# Altium schematic sheet 8_HDMI.SchDoc
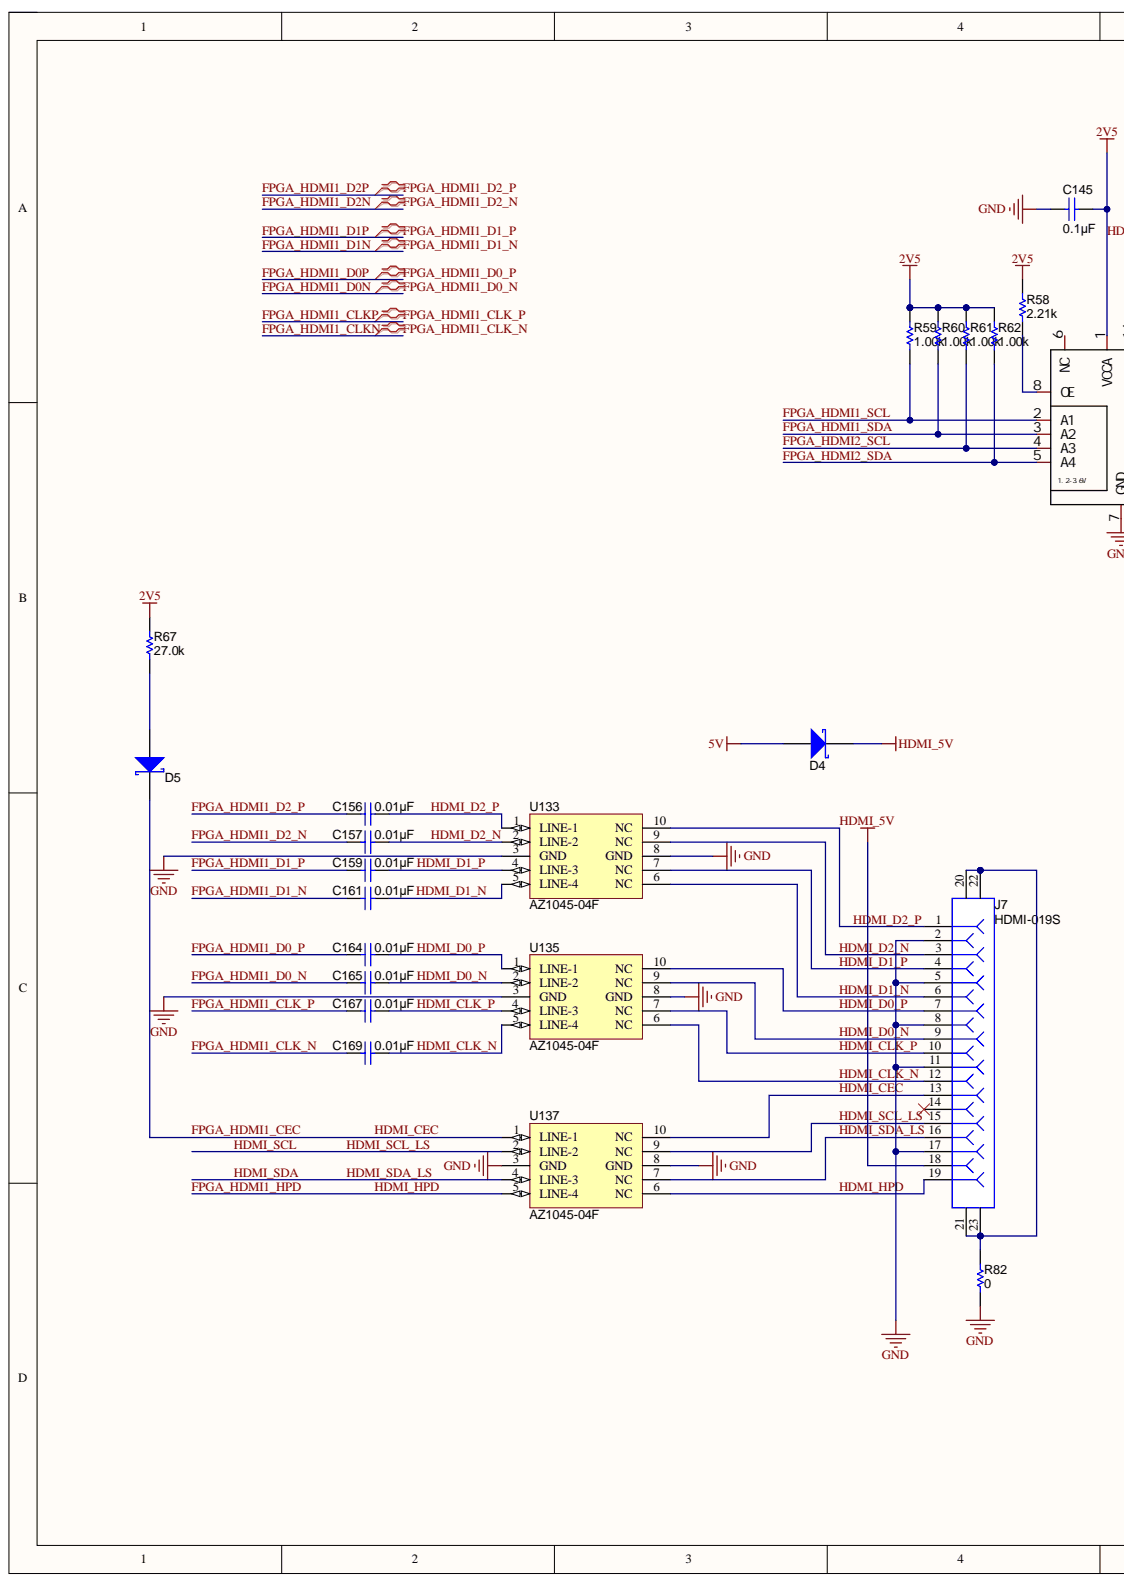
[diagram p0: 8_HDMI.SchDoc, left]
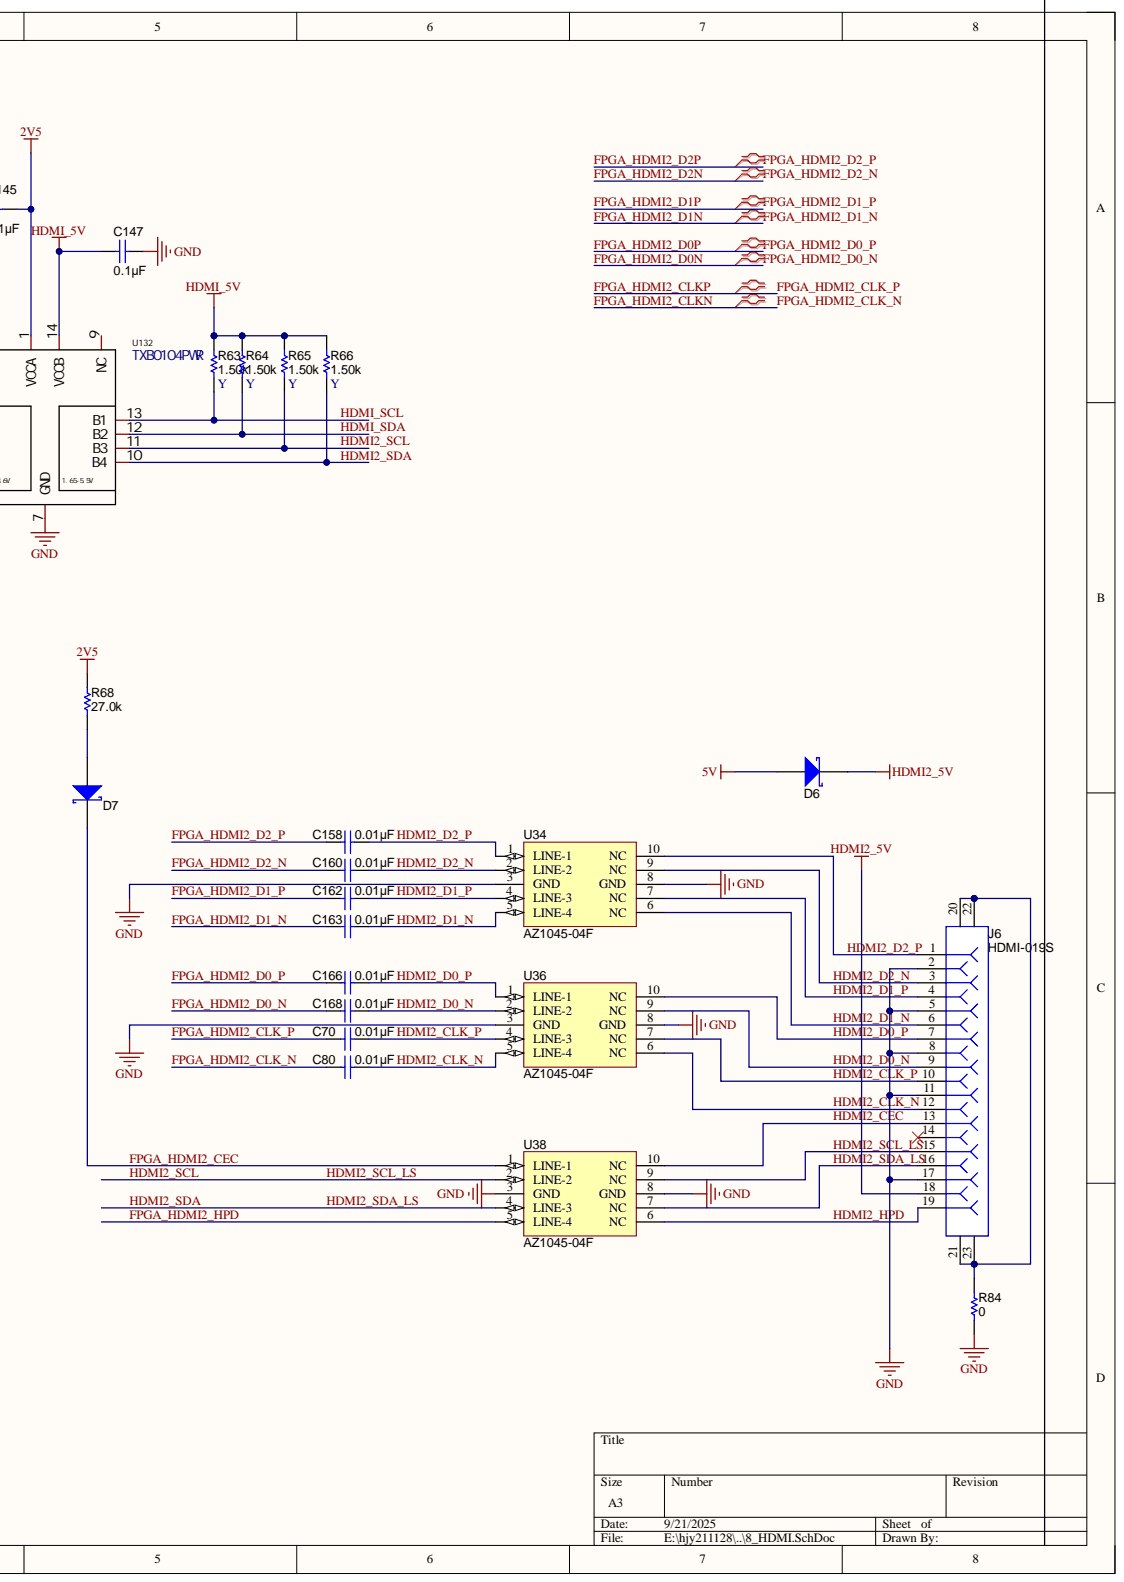
[diagram p1: 8_HDMI.SchDoc, right]

## Parts
C70  ValueDisplayed: 0.01µF  [0402]  (hidden comment: C0402C103J5RACTU)
C80  ValueDisplayed: 0.01µF  [0402]  (hidden comment: C0402C103J5RACTU)
C145  ValueDisplayed: 0.1µF  [0603]  (hidden comment: C0603C104J3RAC)
C147  ValueDisplayed: 0.1µF  [0603]  (hidden comment: C0603C104J3RAC)
C156  ValueDisplayed: 0.01µF  [0402]  (hidden comment: C0402C103J5RACTU)
C157  ValueDisplayed: 0.01µF  [0402]  (hidden comment: C0402C103J5RACTU)
C158  ValueDisplayed: 0.01µF  [0402]  (hidden comment: C0402C103J5RACTU)
C159  ValueDisplayed: 0.01µF  [0402]  (hidden comment: C0402C103J5RACTU)
C160  ValueDisplayed: 0.01µF  [0402]  (hidden comment: C0402C103J5RACTU)
C161  ValueDisplayed: 0.01µF  [0402]  (hidden comment: C0402C103J5RACTU)
C162  ValueDisplayed: 0.01µF  [0402]  (hidden comment: C0402C103J5RACTU)
C163  ValueDisplayed: 0.01µF  [0402]  (hidden comment: C0402C103J5RACTU)
C164  ValueDisplayed: 0.01µF  [0402]  (hidden comment: C0402C103J5RACTU)
C165  ValueDisplayed: 0.01µF  [0402]  (hidden comment: C0402C103J5RACTU)
C166  ValueDisplayed: 0.01µF  [0402]  (hidden comment: C0402C103J5RACTU)
C167  ValueDisplayed: 0.01µF  [0402]  (hidden comment: C0402C103J5RACTU)
C168  ValueDisplayed: 0.01µF  [0402]  (hidden comment: C0402C103J5RACTU)
C169  ValueDisplayed: 0.01µF  [0402]  (hidden comment: C0402C103J5RACTU)
D4  1N5819  [SOD-123F]  (value not drawn)
D5  1N5819  [SOD-123F]  (value not drawn)
D6  1N5819  [SOD-123F]  (value not drawn)
D7  1N5819  [SOD-123F]  (value not drawn)
J6  HDMI-019S  [CONN_TE_1747981-1]
J7  HDMI-019S  [CONN_TE_1747981-1]
R58  value 2.21k  [0402]  (hidden comment: CRCW04022K21FKED)
R59  value 1.00k  [0603]  (hidden comment: CRCW06031K00FKEA)
R60  value 1.00k  [0603]  (hidden comment: CRCW06031K00FKEA)
R61  value 1.00k  [0603]  (hidden comment: CRCW06031K00FKEA)
R62  value 1.00k  [0603]  (hidden comment: CRCW06031K00FKEA)
R63  Y  value 1.50k  [0603]
R64  Y  value 1.50k  [0603]
R65  Y  value 1.50k  [0603]
R66  Y  value 1.50k  [0603]
R67  value 27.0k  [0603]  (hidden comment: RC0603FR-0727KL)
R68  value 27.0k  [0603]  (hidden comment: RC0603FR-0727KL)
R82  value 0  [0603]  (hidden comment: CRCW06030000Z0EA)
R84  value 0  [0603]  (hidden comment: CRCW06030000Z0EA)
U34  AZ1045-04F  [DQA0010A]
U36  AZ1045-04F  [DQA0010A]
U38  AZ1045-04F  [DQA0010A]
U132  TXB0104PWR  [TSSOP-14_L5.0-W4.4-P0.65-LS6.4-BL]
U133  AZ1045-04F  [DQA0010A]
U135  AZ1045-04F  [DQA0010A]
U137  AZ1045-04F  [DQA0010A]

## Nets
2V5: C145.1 R58.2 R59.2 R60.2 R61.2 R62.2 R67.2 R68.2 U132.1(VCCA)
5V: D4.2 D6.2
GND: C145.2 C147.1 J6.2 J6.5 J6.8 J6.11 J6.17 J7.2 J7.5 J7.8 J7.11 J7.17 R82.1 R84.1 U34.3(GND) U34.8(GND) U36.3(GND) U36.8(GND) U38.3(GND) U38.8(GND) U132.7(GND) U133.3(GND) U133.8(GND) U135.3(GND) U135.8(GND) U137.3(GND) U137.8(GND)
HDMI2_5V: D6.1 J6.18
HDMI_5V: C147.2 D4.1 J7.18 R63.2 R64.2 R65.2 R66.2 U132.14(VCCB)
FPGA_HDMI1_CEC: D5.1 J7.13 U137.1(LINE-1) U137.10(NC)
FPGA_HDMI1_CLK_N: C169.2
FPGA_HDMI1_CLK_P: C167.2
FPGA_HDMI1_D0_N: C165.2
FPGA_HDMI1_D0_P: C164.2
FPGA_HDMI1_D1_N: C161.2
FPGA_HDMI1_D1_P: C159.2
FPGA_HDMI1_D2_N: C157.2
FPGA_HDMI1_D2_P: C156.2
FPGA_HDMI1_HPD: J7.19 U137.5(LINE-4) U137.6(NC)
FPGA_HDMI1_SCL: R59.1 U132.2(A1)
FPGA_HDMI1_SDA: R60.1 U132.3(A2)
FPGA_HDMI2_CEC: D7.1 U38.1(LINE-1)
FPGA_HDMI2_CLK_N: C80.2
FPGA_HDMI2_CLK_P: C70.2
FPGA_HDMI2_D0_N: C168.2
FPGA_HDMI2_D0_P: C166.2
FPGA_HDMI2_D1_N: C163.2
FPGA_HDMI2_D1_P: C162.2
FPGA_HDMI2_D2_N: C160.2
FPGA_HDMI2_D2_P: C158.2
FPGA_HDMI2_HPD: U38.5(LINE-4)
FPGA_HDMI2_SCL: R61.1 U132.4(A3)
FPGA_HDMI2_SDA: R62.1 U132.5(A4)
HDMI2_CEC: J6.13 U38.10(NC)
HDMI2_CLK_N: C80.1 J6.12 U36.5(LINE-4) U36.6(NC)
HDMI2_CLK_P: C70.1 J6.10 U36.4(LINE-3) U36.7(NC)
HDMI2_D0_N: C168.1 J6.9 U36.2(LINE-2) U36.9(NC)
HDMI2_D0_P: C166.1 J6.7 U36.1(LINE-1) U36.10(NC)
HDMI2_D1_N: C163.1 J6.6 U34.5(LINE-4) U34.6(NC)
HDMI2_D1_P: C162.1 J6.4 U34.4(LINE-3) U34.7(NC)
HDMI2_D2_N: C160.1 J6.3 U34.2(LINE-2) U34.9(NC)
HDMI2_D2_P: C158.1 J6.1 U34.1(LINE-1) U34.10(NC)
HDMI2_HPD: J6.19 U38.6(NC)
HDMI2_SCL: J6.15 R65.1 U38.2(LINE-2) U38.9(NC) U132.11(B3)
HDMI2_SDA: J6.16 R66.1 U38.4(LINE-3) U38.7(NC) U132.10(B4)
HDMI_CLK_N: C169.1 J7.12 U135.5(LINE-4) U135.6(NC)
HDMI_CLK_P: C167.1 J7.10 U135.4(LINE-3) U135.7(NC)
HDMI_D0_N: C165.1 J7.9 U135.2(LINE-2) U135.9(NC)
HDMI_D0_P: C164.1 J7.7 U135.1(LINE-1) U135.10(NC)
HDMI_D1_N: C161.1 J7.6 U133.5(LINE-4) U133.6(NC)
HDMI_D1_P: C159.1 J7.4 U133.4(LINE-3) U133.7(NC)
HDMI_D2_N: C157.1 J7.3 U133.2(LINE-2) U133.9(NC)
HDMI_D2_P: C156.1 J7.1 U133.1(LINE-1) U133.10(NC)
HDMI_SCL: J7.15 R63.1 U132.13(B1) U137.2(LINE-2) U137.9(NC)
HDMI_SDA: J7.16 R64.1 U132.12(B2) U137.4(LINE-3) U137.7(NC)
NetD5_2: D5.2 R67.1
NetD7_2: D7.2 R68.1
NetJ6_20: J6.20 J6.21 J6.22 J6.23 R84.2
NetJ7_20: J7.20 J7.21 J7.22 J7.23 R82.2
NetR58_1: R58.1 U132.8(OE)

Not connected: J6 14; J7 14; U132 6(NC) 9(NC)

## Library data of the parts
C70 C80 C156-C169 = C0402C103J5RACTU: CAP, CERM, 0.01 µF, 50 V, +/- 5%, X7R, 0402; Manufacturer: Kemet; Cap_F: 0.00000001; CompType: Capacitor; Polarized: N; TaMax_C: 125; TaMin_C: -55; Tolerance_%: 5; U/M: EA; Value: 0.01uF; Value1: Unknown; Value2: 50V; VDC_V: 50; Cap_Case: 0402; Component Kind: Standard; ComponentLink1Description: Datasheet link; ComponentLink1URL: http://www.kemet.com/Lists/ProductCatalog/Attachments/53/KEM_C1002_X7R_SMD.pdf; LatestRevisionDate: 2015-05-02; MountType: SMT; PackageReference: 0402; PartNumber: C0402C103J5RACTU; Published: 2008-06-19; RoHS: Y; Signal Integrity: Cap; Simulation: Cap; Supplier 1: Digi-Key; Supplier 2: Mouser; Supplier 3: Farnell; Supplier Part Number 1: 399-7758-1-ND; Supplier Part Number 2: 80-C0402C103J5R; Supplier Part Number 3: 2413922; SymbolVersion: 2008-07-09; Technology: Ceramic; TempCo: X7R; Library Name: 底板集成库.IntLib; Publisher: Texas Instruments
C145 C147 = C0603C104J3RAC: CAP, CERM, 0.1 µF, 25 V, +/- 5%, X7R, 0603; Manufacturer: Kemet; Cap_F: 0.0000001; CompType: Capacitor; Polarized: N; TaMax_C: 125; TaMin_C: -55; Tolerance_%: 5; U/M: EA; Value: 0.1uF; Value1: Unknown; Value2: 25V; VDC_V: 25; Cap_Case: 0603; Component Kind: Standard; ComponentLink1Description: Datasheet link; ComponentLink1URL: http://www.kemet.com/kemet/web/homepage/kechome.nsf/vapubfiles/F3102_Y5V.pdf/$file/F3102_Y5V.pdf; LatestRevisionDate: 2015-05-02; MountType: SMT; PackageReference: 0603; PartNumber: C0603C104J3RAC; Published: 2008-06-19; RoHS: Y; Signal Integrity: Cap; Simulation: Cap; Supplier 1: Farnell; Supplier Part Number 1: 1650833; SymbolVersion: 2008-07-09; Technology: Ceramic; TempCo: X7R; Library Name: 底板集成库.IntLib; Publisher: Texas Instruments
D4-D7 = PMEG2010AEH,115: Diode, Schottky, 20 V, 1 A, SOD-123F; Manufacturer: NXP Semiconductor; IGNORE: N; Io_A: 1; TJmax_C: 150; U/M: EA; Value: 20V; Value1: 1A; Value2: 0.43V; Vf_V: 0.43; Vr_V: 20; Component Kind: Standard; ComponentLink1Description: Datasheet link; ComponentLink1URL: http://www.nxp.com/documents/data_sheet/PMEG2010AEH_PMEG2010AET.pdf; CompType: Diode; LatestRevisionDate: 2015-04-30; MountType: SMT; PackageReference: SOD-123F; PartNumber: 1N5819; Published: 2008-06-26; RoHS: Y; Signal Integrity: Diode; Supplier 1: Digi-Key; Supplier 2: Mouser; Supplier 3: Farnell; Supplier Part Number 1: 568-4112-1-ND; Supplier Part Number 2: 771-PMEG2010AEH-T/R; Supplier Part Number 3: 2371105; SymbolVersion: 2008-12-08; Type: Schottky; Library Name: 底板集成库.IntLib; Publisher: Texas Instruments
J6 J7 = 1747981-1: Connector, HDMI, 19-Pos Recept, SMT; Manufacturer: TE Connectivity; SymbolCreator: a0799721; U/M: EA; Component Kind: Standard; ComponentLink1Description: Datasheet Link; ComponentLink1URL: http://www.te.com/commerce/DocumentDelivery/DDEController?Action=showdoc&DocId=Customer+Drawing%7F1747981%7FF3%7Fpdf%7FEnglish%7FENG_CD_1747981_F3.pdf%7F1747981-1; CompType: Connector; LatestRevisionDate: 2014-11-22; MountType: SMT; PackageReference: 15.0x6.08x11.55mm; PartNumber: HDMI-019S; RoHS: Y; Supplier 1: Digi-Key; Supplier 2: Mouser; Supplier 3: Farnell; Supplier Part Number 1: A35071CT-ND; Supplier Part Number 2: 571-1747981-1; Supplier Part Number 3: 1939411; SymbolVersion: 04/03/2013; Library Name: 底板集成库.IntLib; Publisher: Texas Instruments
R58 = CRCW04022K21FKED: RES, 2.21 k, 1%, 0.063 W, 0402; Manufacturer: Vishay-Dale; CompType: Resistor; EIA_Code: E96; Power_W: 0.063; Res_ohm: 2210; TempCo: 100 ppm/C; Tolerance_%: 1; U/M: EA; Value2: 0.063W; Value_Code: 2k21; VDC_V: 50; Component Kind: Standard; ComponentLink1Description: Datasheet link; ComponentLink1URL: http://www.vishay.com/docs/20035/dcrcwe3.pdf; LatestRevisionDate: 2015-05-01; MountType: SMT; PackageReference: 0402; PartNumber: CRCW04022K21FKED; Published: 2008-06-26; RoHS: Y; Signal Integrity: Resistor; Simulation: Resistor; Supplier 1: Digi-Key; Supplier 2: Mouser; Supplier 3: Farnell; Supplier Part Number 1: 541-2.21KLCT-ND; Supplier Part Number 2: 71-CRCW0402-2.21K-E3; Supplier Part Number 3: 1469689; SymbolVersion: 2009-05-29; Technology: Thick Film; Value1: 1%; ValueDisplayed: 2.21k; Library Name: ANLOGIC开发板封装库.IntLib; Publisher: Texas Instruments
R59-R62 = CRCW06031K00FKEA: RES, 1.00 k, 1%, 0.1 W, 0603; Manufacturer: Vishay-Dale; CompType: Resistor; EIA_Code: E96; Power_W: 0.1; Res_ohm: 1000; TempCo: 100 ppm/C; Tolerance_%: 1; U/M: EA; Value2: 0.1W; Value_Code: 1k00; VDC_V: 75; Component Kind: Standard; ComponentLink1Description: Datasheet link; ComponentLink1URL: http://www.vishay.com/docs/20035/dcrcwe3.pdf; LatestRevisionDate: 2015-05-02; MountType: SMT; PackageReference: 0603; PartNumber: CRCW06031K00FKEA; Published: 2008-06-26; RoHS: Y; Signal Integrity: Resistor; Simulation: Resistor; Supplier 1: Digi-Key; Supplier 2: Mouser; Supplier 3: Farnell; Supplier Part Number 1: 541-1.00KHCT-ND; Supplier Part Number 2: 71-CRCW0603-1.0K-E3; Supplier Part Number 3: 1469740; SymbolVersion: 2009-05-29; Technology: Thick Film; Value1: 1%; ValueDisplayed: 1.00k; Library Name: 底板集成库.IntLib; Publisher: Texas Instruments
R63-R66 = CRCW06031K50FKEA: RES, 1.50 k, 1%, 0.1 W, 0603; Manufacturer: Vishay-Dale; CompType: Resistor; EIA_Code: E96; Power_W: 0.1; Res_ohm: 1500; TempCo: 100 ppm/C; Tolerance_%: 1; U/M: EA; Value2: 0.1W; Value_Code: 1k50; VDC_V: 75; Component Kind: Standard; ComponentLink1Description: Datasheet link; ComponentLink1URL: http://www.vishay.com/docs/20035/dcrcwe3.pdf; INGNORE: Y; LatestRevisionDate: 2015-05-02; MountType: SMT; PackageReference: 0603; PartNumber: CRCW06031K50FKEA; Published: 2008-06-26; RoHS: Y; Signal Integrity: Resistor; Simulation: Resistor; Supplier 1: Digi-Key; Supplier 2: Mouser; Supplier 3: Farnell; Supplier Part Number 1: 541-1.50KHCT-ND; Supplier Part Number 2: 71-CRCW0603-1.5K-E3; Supplier Part Number 3: 1469743; SymbolVersion: 2009-05-29; Technology: Thick Film; Value1: 1%; ValueDisplayed: 1.50k; Library Name: 底板集成库.IntLib; Publisher: Texas Instruments
R67 R68 = RC0603FR-0727KL: RES, 27.0 k, 1%, 0.1 W, 0603; Manufacturer: Yageo America; CompType: Resistor; Power_W: 0.1; Res_ohm: 27000; TempCo: 200 ppm/C; Tolerance_%: 1; U/M: EA; Value2: 0.1W; Value_Code: 27k0; VDC_V: 150; Component Kind: Standard; ComponentLink1Description: Datasheet link; ComponentLink1URL: http://www.yageo.com/pdf/Pu-RC0603_51_PbFree_L_2.pdf; LatestRevisionDate: 2015-05-02; MountType: SMT; PackageReference: 0603; PartNumber: RC0603FR-0727KL; Published: 2008-06-26; RoHS: Y; Signal Integrity: Resistor; Simulation: Resistor; Supplier 1: Digi-Key; Supplier 2: Mouser; Supplier 3: Farnell; Supplier Part Number 1: 311-27.0KHRCT-ND; Supplier Part Number 2: 603-RC0603FR-0727KL; Supplier Part Number 3: 9238654; SymbolVersion: 2009-05-29; Technology: Thick Film; Value1: 1%; ValueDisplayed: 27.0k; Library Name: 底板集成库.IntLib; Publisher: Texas Instruments
R82 R84 = CRCW06030000Z0EA: RES, 0, 5%, 0.1 W, 0603; Manufacturer: Vishay-Dale; CompType: Resistor; EIA_Code: E24; Power_W: 0.1; TempCo: 200 ppm/C; Tolerance_%: 5; U/M: EA; Value2: 0.1W; Value_Code: 0000; VDC_V: 75; Component Kind: Standard; ComponentLink1Description: Datasheet link; ComponentLink1URL: http://www.vishay.com/docs/20035/dcrcwe3.pdf; LatestRevisionDate: 2015-05-02; MountType: SMT; PackageReference: 0603; PartNumber: CRCW06030000Z0EA; Published: 2008-06-26; Res_ohm: 0; RoHS: Y; Signal Integrity: Resistor; Simulation: Resistor; Supplier 1: Digi-Key; Supplier 2: Mouser; Supplier 3: Farnell; Supplier Part Number 1: 541-0.0GCT-ND; Supplier Part Number 2: 71-CRCW0603-0-E3; Supplier Part Number 3: 1469739; SymbolVersion: 2009-05-29; Technology: Thick Film; Value1: 5%; ValueDisplayed: 0; Library Name: 底板集成库.IntLib; Publisher: Texas Instruments
U34 U36 U38 U133 U135 U137 = AZ1045-04F: 1, 4, 6 CHANNEL PROTECTION SOLUTION FOR SUPER-SPEED (UP TO 6 GBPS) INTERFACE, DQA0010A; Alternate Manufacturer: Texas Instruments; CompType: IC; SymbolCreator: a0411947; U/M: EA; Component Kind: Standard; ComponentLink1Description: Datasheet Link; ComponentLink1URL: http://www.ti.com/lit/gpn/tpd4e05u06; LatestRevisionDate: 2015-05-05; Manufacturer: Texas Instruments; MountType: SMT; PackageReference: DQA0010A; PartNumber: AZ1045-04F; RoHS: Y; SymbolVersion: 2013-08-01; Library Name: 底板集成库.IntLib; Publisher: Texas Instruments
U132 = TXB0104PWR: Manufacturer: TI(德州仪器); Add into BOM: yes; Channel Type: 双向; Channels per Circuit: 4; Data Rate: 100Mbps; Number of Circuits: 1; Output Type: 三态，非反相; Supply Voltage (VCCA): 1.2V~3.6V; Supply Voltage (VCCB): 1.65V~5.5V; Translator Type: 电压电平; Component Kind: Standard; Convert to PCB: yes; Datasheet: https://atta.szlcsc.com/upload/public/pdf/source/20151029/1457707542080.pdf; Device: TXB0104PWR; Features: 自动方向检测; Input Signal: -; JLCPCB Part Class: Extended Part; LCSC Part Name: TXB0104PWR; Manufacturer Part: TXB0104PWR; Name: TXB0104PWR; Operating Temperature: -40℃~+85℃; Output Signal: -; Supplier: LCSC; Supplier Footprint: TSSOP-14; Supplier Part: C60708; Symbol: TXB0104PWR; Library Name: 底板集成库.IntLib
